# Altium schematic sheet ArduinoUnoShield.SchDoc
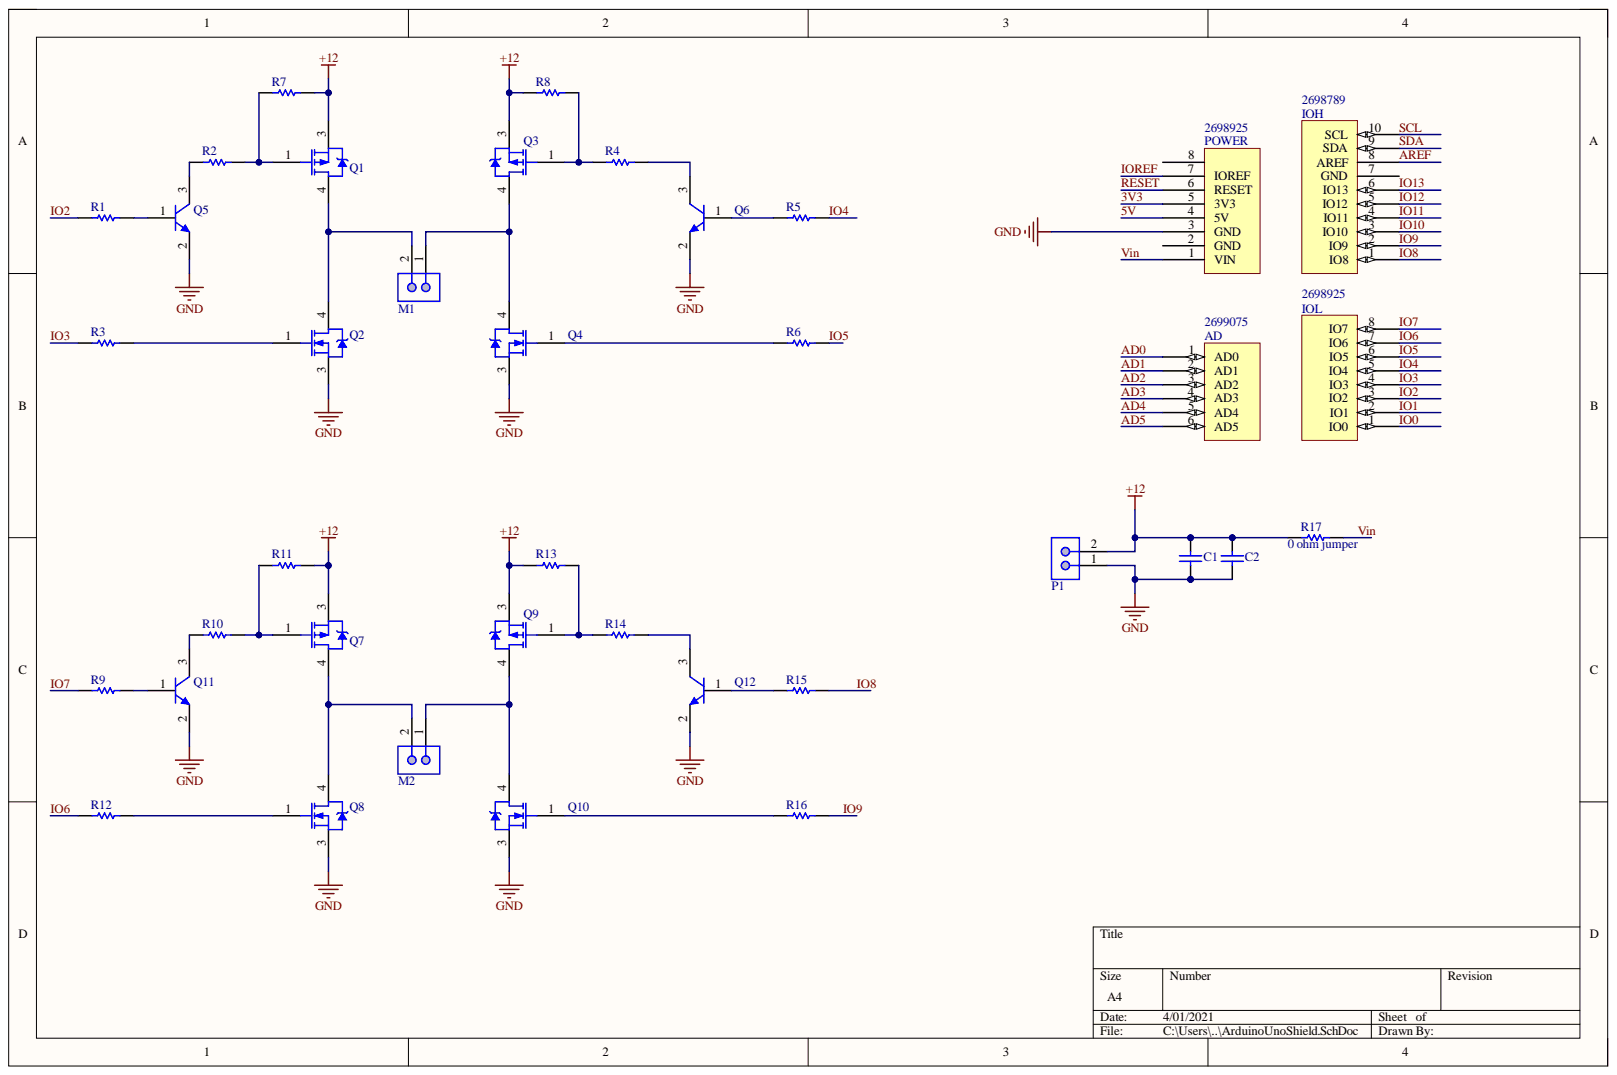
[diagram p0: ArduinoUnoShield.SchDoc]

## Parts
AD  AD  Supplier Part Number 4: 2699075  [Header 6x1 8.5mm]
C1  CAP 100nF 50V 1206(3216)  [CAPC1206(3216)100_L]  (value not drawn)
C2  CAP 100nF 50V 1206(3216)  [CAPC1206(3216)100_L]  (value not drawn)
IOH  IOH  Supplier Part Number 3: 2698789  [Header 10x1 8.5mm]
IOL  IOL  Supplier Part Number 4: 2698925  [Header 8x1 8.5mm]
M1  68000-102HLF  [AMPH-68000-102HLF_V]  (value not drawn)
M2  68000-102HLF  [AMPH-68000-102HLF_V]  (value not drawn)
P1  68000-102HLF  [AMPH-68000-102HLF_V]  (value not drawn)
POWER  Supplier Part Number 4: 2698925  [Header 8x1 8.5mm]  (hidden comment: POWER_UNO)
Q1  IRF9630STRLPBF‎  [ONSC-D2PAK-3-418B-04_V]  (value not drawn)
Q2  IRF630NSTRLPBF‎  [ONSC-D2PAK-3-418B-04_V]  (value not drawn)
Q3  IRF9630STRLPBF‎  [ONSC-D2PAK-3-418B-04_V]  (value not drawn)
Q4  IRF630NSTRLPBF‎  [ONSC-D2PAK-3-418B-04_V]  (value not drawn)
Q5  BC817-16LT1G  [ONSC-SOT-23-3-318-08_V]  (value not drawn)
Q6  BC817-16LT1G  [ONSC-SOT-23-3-318-08_V]  (value not drawn)
Q7  IRF9630STRLPBF‎  [ONSC-D2PAK-3-418B-04_V]  (value not drawn)
Q8  IRF630NSTRLPBF‎  [ONSC-D2PAK-3-418B-04_V]  (value not drawn)
Q9  IRF9630STRLPBF‎  [ONSC-D2PAK-3-418B-04_V]  (value not drawn)
Q10  IRF630NSTRLPBF‎  [ONSC-D2PAK-3-418B-04_V]  (value not drawn)
Q11  BC817-16LT1G  [ONSC-SOT-23-3-318-08_V]  (value not drawn)
Q12  BC817-16LT1G  [ONSC-SOT-23-3-318-08_V]  (value not drawn)
R1  CRCW1206220KFKEA  [RESC3216X60X45NL10T20]  (value not drawn)
R2  CRCW1206220KFKEA  [RESC3216X60X45NL10T20]  (value not drawn)
R3  CRCW1206220KFKEA  [RESC3216X60X45NL10T20]  (value not drawn)
R4  CRCW1206220KFKEA  [RESC3216X60X45NL10T20]  (value not drawn)
R5  CRCW1206220KFKEA  [RESC3216X60X45NL10T20]  (value not drawn)
R6  CRCW1206220KFKEA  [RESC3216X60X45NL10T20]  (value not drawn)
R7  CRCW1206220KFKEA  [RESC3216X60X45NL10T20]  (value not drawn)
R8  CRCW1206220KFKEA  [RESC3216X60X45NL10T20]  (value not drawn)
R9  CRCW1206220KFKEA  [RESC3216X60X45NL10T20]  (value not drawn)
R10  CRCW1206220KFKEA  [RESC3216X60X45NL10T20]  (value not drawn)
R11  CRCW1206220KFKEA  [RESC3216X60X45NL10T20]  (value not drawn)
R12  CRCW1206220KFKEA  [RESC3216X60X45NL10T20]  (value not drawn)
R13  CRCW1206220KFKEA  [RESC3216X60X45NL10T20]  (value not drawn)
R14  CRCW1206220KFKEA  [RESC3216X60X45NL10T20]  (value not drawn)
R15  CRCW1206220KFKEA  [RESC3216X60X45NL10T20]  (value not drawn)
R16  CRCW1206220KFKEA  [RESC3216X60X45NL10T20]  (value not drawn)
R17  CRCW1206220KFKEA  [RESC3216X60X45NL10T20]  (value not drawn)

## Nets
+12: C1.1 C2.1 P1.2 Q1.3 Q3.3 Q7.3 Q9.3 R7.2 R8.1 R11.2 R13.1 R17.1
GND: C1.2 C2.2 P1.1 POWER.3(GND) Q2.3 Q4.3 Q5.2 Q6.2 Q8.3 Q10.3 Q11.2 Q12.2
3V3: POWER.5(3V3)
5V: POWER.4(5V)
AD0: AD.1(AD0)
AD1: AD.2(AD1)
AD2: AD.3(AD2)
AD3: AD.4(AD3)
AD4: AD.5(AD4)
AD5: AD.6(AD5)
AREF: IOH.8(AREF)
IO0: IOL.1(IO0)
IO1: IOL.2(IO1)
IO2: IOL.3(IO2) R1.1
IO3: IOL.4(IO3) R3.1
IO4: IOL.5(IO4) R5.2
IO5: IOL.6(IO5) R6.2
IO6: IOL.7(IO6) R12.1
IO7: IOL.8(IO7) R9.1
IO8: IOH.1(IO8) R15.2
IO9: IOH.2(IO9) R16.2
IO10: IOH.3(IO10)
IO11: IOH.4(IO11)
IO12: IOH.5(IO12)
IO13: IOH.6(IO13)
IOREF: POWER.7(IOREF)
RESET: POWER.6(RESET)
SCL: IOH.10(SCL)
SDA: IOH.9(SDA)
Vin: POWER.1(VIN) R17.2
NetM1_1: M1.1 Q3.4 Q4.4
NetM1_2: M1.2 Q1.4 Q2.4
NetM2_1: M2.1 Q9.4 Q10.4
NetM2_2: M2.2 Q7.4 Q8.4
NetQ1_1: Q1.1 R2.2 R7.1
NetQ2_1: Q2.1 R3.2
NetQ3_1: Q3.1 R4.1 R8.2
NetQ4_1: Q4.1 R6.1
NetQ5_1: Q5.1 R1.2
NetQ5_3: Q5.3 R2.1
NetQ6_1: Q6.1 R5.1
NetQ6_3: Q6.3 R4.2
NetQ7_1: Q7.1 R10.2 R11.1
NetQ8_1: Q8.1 R12.2
NetQ9_1: Q9.1 R13.2 R14.1
NetQ10_1: Q10.1 R16.1
NetQ11_1: Q11.1 R9.2
NetQ11_3: Q11.3 R10.1
NetQ12_1: Q12.1 R15.1
NetQ12_3: Q12.3 R14.2

Not connected: IOH 7(GND); POWER 2(GND) 8

## Library data of the parts
C1 C2 = CMP-1037-04202-1: CAP 100nF 50V ±10% 1206 (3216 Metric) Thickness 1mm SMD; Case-EIA: 1206; Case-Metric: 3216; Tolerance: Â±10%; Component Kind: Standard; Library Name: Altium Content Vault; Max Thickness: 1 mm; Rated Voltage: 50 V; Technology: SMT; Value: 100nF
M1 M2 P1 = CMP-2000-05666-1: Male Header, Pitch 2.54 mm, 1 x 2 Position, Height 8.38 mm, Tail Length 2.41 mm, RoHS, Bulk; Brand: FCI; Current Rating: 3A; Insulation Resistance: 5000MOhms; Mating Post Length: 5.84mm; Mounting Angle: Vertical; Number of Positions: 2; Pitch: 2.54mm; Voltage Rating: 1.5kV; Component Kind: Standard; Contact Gender: Pin(Male); Contact Material: PhosphorBronze; Contact Plating: Gold/GTX; Datasheet URL: https://datasheet.ciiva.com/5149/68000-274228-5149165.pdf; Datasheet Version: Rev. F, 04/2006; Factory Pack Quantity: 100; Flammability Rating: UL94V-0; Housing Material: Thermoplastic; Library Name: Altium Content Vault; Manufacturer: FCI; Manufacturer URL: http://www.amphenol.com/; Mounting Style: ThroughHole; Mounting Technology: ThroughHole; Number of Positions / Contacts: 2; Number of Rows: 1; Operating Temperature Range: -65Cto+125C; Package Description: Male Header, Pitch 2.54 mm, 1 x 2 Position, Height 8.38 mm, Tail Length 2.41 mm; Package Reference: 68000-102HLF; Packaging: Bulk; Product Category: Headers&WireHousings; Product Type: Headers; RoHS: Details; Series: 68000; Termination Post Length: 2.41mm; Termination Style: ThroughHole; Type: Unshrouded
Q1 Q3 Q7 Q9 = CMP-1058-00099-1: Power MOSFET, 50 A, 30 V, Logic Level, P-Channel, 3-Pin D2PAK, Pb-Free, Tape and Reel; Manufacturer: On Semiconductor; PartNumber: MTB50P03HDLT4G; Channel Polarity: P Channel; Ciss Typ (pF): 3500; Configuration: Single; Coss Typ (pF): 1550; Crss Typ (pF): 550; Qrr Typ (nC): 246; V(BR)DSS Min (V): 30; VGS Max (V): 15; VGS(th) Max (V): 2; Component Kind: Standard; ComponentLink1Description: Manufacturer URL; ComponentLink1URL: http://www.onsemi.com/; ComponentLink2Description: Datasheet; ComponentLink2URL: http://www.onsemi.cn/pub_link/Collateral/MTB50P03HDL-D.PDF; ComponentLink3Description: Package Specification; ComponentLink3URL: http://www.onsemi.com/pub_link/Collateral/418B-04.PDF; DatasheetVersion: Rev. 6; Library Name: Altium Content Vault; Mounting Technology: Surface Mount; Package Type: D2PAK-3; PackageDescription: 3-Pin Flange Mount, Body 9.145 x 9.97 mm, Pitch 2.54 mm; PackageReference: D2PAK-3-418B-04; PackageVersion: Rev. K, 09/2008; Packing: Tape and Reel; RoHS: Pb-Free
Q2 Q4 Q8 Q10 = CMP-1058-00123-1: Power MOSFET, 60 V, 60 A, N-Channel, 3-Pin D2PAK, Pb-Free, Tape and Reel; Manufacturer: On Semiconductor; PartNumber: NTB60N06T4G; Channel Polarity: N Channel; Ciss Typ (pF): 2300; Configuration: Single; Coss Typ (pF): 660; Crss Typ (pF): 144; Qrr Typ (nC): 146; V(BR)DSS Min (V): 60; VGS(th) Max (V): 4; Component Kind: Standard; ComponentLink1Description: Manufacturer URL; ComponentLink1URL: http://www.onsemi.com/; ComponentLink2Description: Datasheet; ComponentLink2URL: http://www.onsemi.cn/pub_link/Collateral/NTB60N06-D.PDF; ComponentLink3Description: Package Specification; ComponentLink3URL: http://www.onsemi.com/pub_link/Collateral/418B-04.PDF; DatasheetVersion: Rev. 0; Library Name: Altium Content Vault; Mounting Technology: Surface Mount; Package Type: D2PAK-3; PackageDescription: 3-Pin Flange Mount, Body 9.145 x 9.97 mm, Pitch 2.54 mm; PackageReference: D2PAK-3-418B-04; PackageVersion: Rev. K, 09/2008; Packing: Tape and Reel; RoHS: Pb-Free
Q5 Q6 Q11 Q12 = CMP-1048-00736-1: General Purpose Transistor, NPN Silicon, 3-Pin SOT-23, Pb-Free, Tape and Reel; Manufacturer: On Semiconductor; fT Min (MHz): 100; IC Continuous (A): 0.5; Component Kind: Standard; ComponentLink1Description: Manufacturer URL; ComponentLink1URL: http://www.onsemi.com/; ComponentLink2Description: Datasheet; ComponentLink2URL: http://www.onsemi.com/pub_link/Collateral/BC817-16LT1-D.PDF; ComponentLink3Description: Package Specification; ComponentLink3URL: http://www.onsemi.cn/pub_link/Collateral/318-08.PDF; DatasheetVersion: Rev. 12; Library Name: Altium Content Vault; Mounting Technology: Surface Mount; PackageDescription: 3-Pin Small Outline Transistor, Body 2.92 x 1.3 mm, Pitch 0.95 mm; PackageReference: SOT-23-3-318-08; PackageVersion: Rev. AP, 11/2009; Packing: Tape and Reel; PartNumber: BC817-16LT1G; Polarity: NPN; RoHS: TRUE
R1-R17 = CMP-2003-01954-1: Manufacturer: Vishay Dale; Component Kind: Standard; Library Name: Altium Content Vault; Part Number: CRCW1206220KFKEA
AD = AD: Component Kind: Standard; Library Name: Header.SchLib; Supplier 1: Arrow; Supplier 2: Digi-Key; Supplier 3: Arrow; Supplier 4: Arrow; Supplier Part Number 1: 2699089; Supplier Part Number 2: SAM1198-06-ND; Supplier Part Number 3: 2699088
IOH = IOH: Component Kind: Standard; Library Name: Header.SchLib; Supplier 1: Arrow; Supplier 2: Digi-Key; Supplier 3: Arrow; Supplier Part Number 1: 2698803S4475693N1004; Supplier Part Number 2: SAM1198-10-ND
IOL = IOL: Component Kind: Standard; Library Name: Header.SchLib; Supplier 1: Digi-Key; Supplier 2: Arrow; Supplier 3: Arrow; Supplier 4: Arrow; Supplier Part Number 1: SAM1198-08-ND; Supplier Part Number 2: 2698939; Supplier Part Number 3: 2698940
POWER = POWER_UNO: Component Kind: Standard; Library Name: Header.SchLib; Supplier 1: Digi-Key; Supplier 2: Arrow; Supplier 3: Arrow; Supplier 4: Arrow; Supplier Part Number 1: SAM1198-08-ND; Supplier Part Number 2: 2698939; Supplier Part Number 3: 2698940
